# Altium schematic sheet EXOStripController.SchDoc
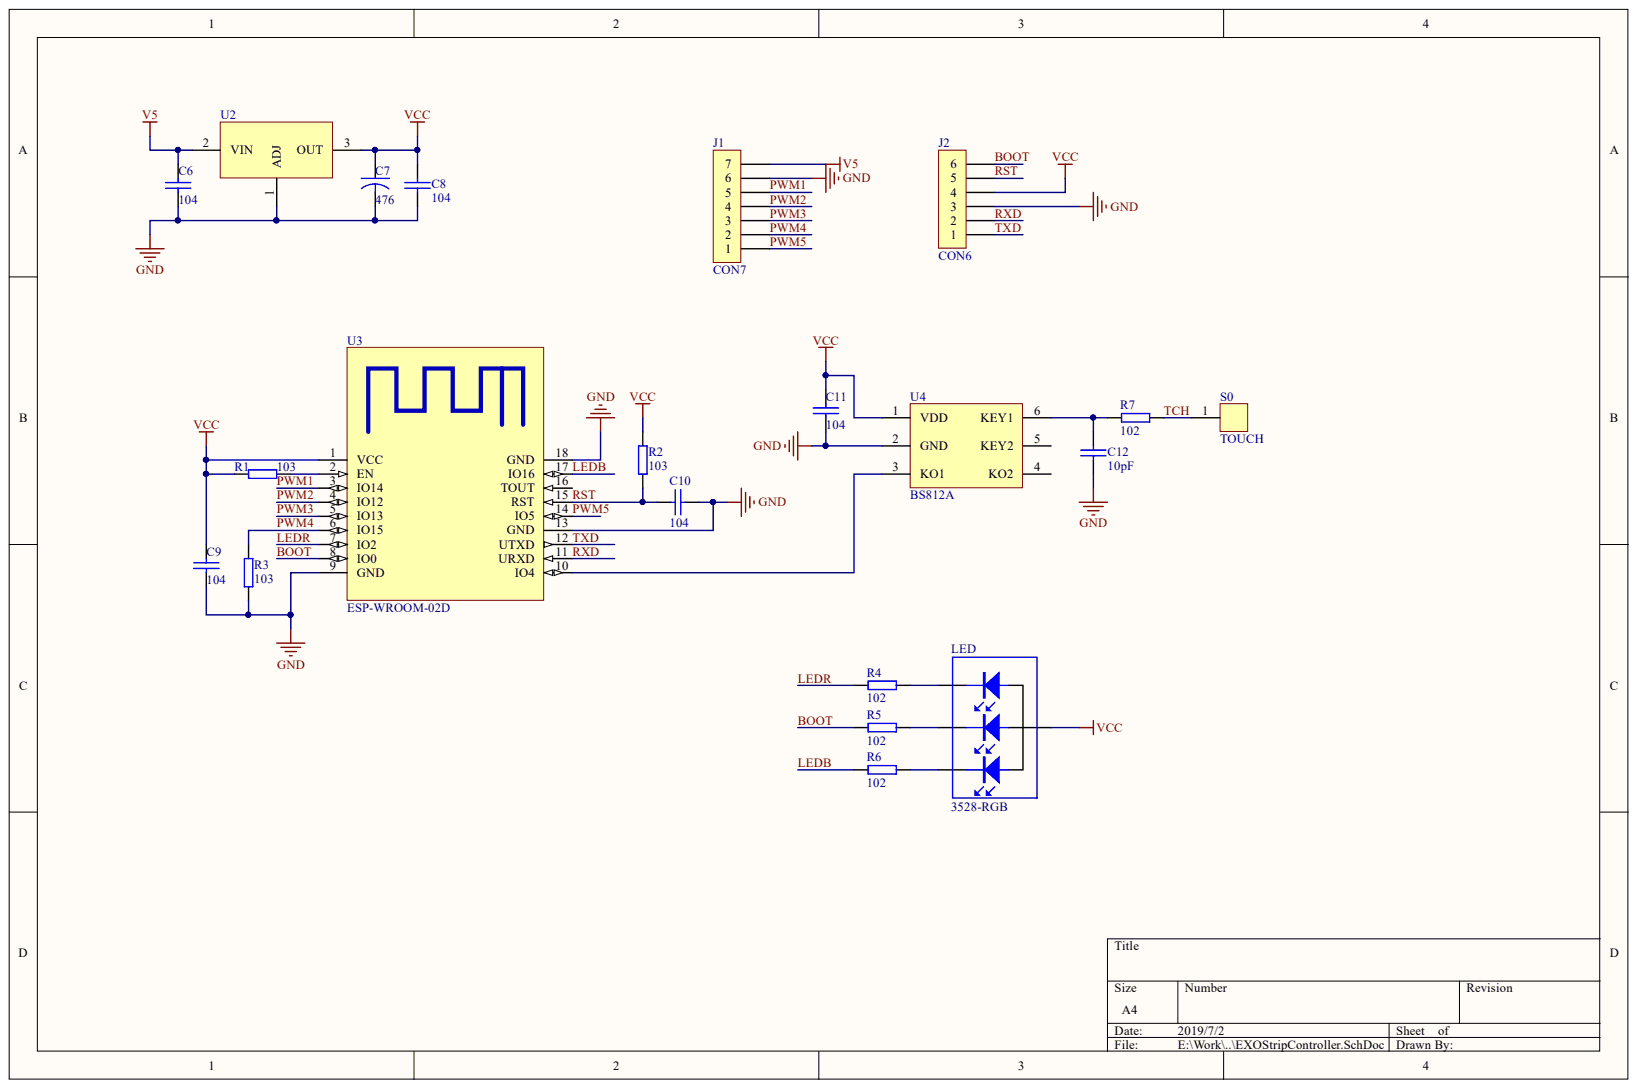
[diagram p0: EXOStripController.SchDoc]

## Parts
C6  104  [0603]
C7  476  [3216]
C8  104  [0603]
C9  104  [0603]
C10  104  [0603]
C11  104  [0603]
C12  10pF  [0603]
J1  CON7  [FPC-1mm*7]
J2  CON6  [J6]
LED  3528-RGB  [3528-RGB]
R1  103  [0603]
R2  103  [0603]
R3  103  [0603]
R4  102  [0603]
R5  102  [0603]
R6  102  [0603]
R7  102  [0603]
S0  TOUCH
U2  LD1117AG33  [SOT223]  (value not drawn)
U3  ESP-WROOM-02D  [ESP-WROOM-02D]
U4  BS812A  [TS0T23-6]

## Nets
GND: C6.2 C7.2 C8.2 C9.1 C10.1 C11.2 C12.2 J1.6 J2.3 R3.1 U2.1 U3.9(GND) U3.13(GND) U3.18(GND) U4.2(GND)
V5: C6.1 J1.7 U2.2
VCC: C7.1 C8.1 C9.2 C11.1 J2.4 LED.4 R1.1 R2.1 U2.3 U3.1(VCC) U4.1(VDD)
BOOT: J2.6 R5.1 U3.8(IO0)
LEDB: R6.1 U3.17(IO16)
LEDR: R4.1 U3.7(IO2)
PWM1: J1.5 U3.3(IO14)
PWM2: J1.4 U3.4(IO12)
PWM3: J1.3 U3.5(IO13)
PWM4: J1.2 R3.2 U3.6(IO15)
PWM5: J1.1 U3.14(IO5)
RST: C10.2 J2.5 R2.2 U3.15(RST)
RXD: J2.2 U3.11(URXD)
TCH: R7.2 S0.1
TXD: J2.1 U3.12(UTXD)
NetC12_1: C12.1 R7.1 U4.6(KEY1)
NetLED_1: LED.1 R4.2
NetLED_2: LED.2 R5.2
NetLED_3: LED.3 R6.2
NetR1_2: R1.2 U3.2(EN)
NetU3_10: U3.10(IO4) U4.3(KO1)

Not connected: U3 16(TOUT); U4 4(KO2) 5(KEY2)

## Library data of the parts
C6 C8-C12 = CAP: Capacitor; Component Kind: Standard; Library Name: 郑州创亿达照明原理图元件库.SCHLIB
C7 = CAPACITOR: Component Kind: Standard; Description: Capacitor; Library Name: 郑州创亿达照明原理图元件库.SCHLIB
J1 = CON7: Connector; Component Kind: Standard; Library Name: 郑州创亿达照明原理图元件库.SCHLIB
J2 = CON6: Connector; Component Kind: Standard; Library Name: 郑州创亿达照明原理图元件库.SCHLIB
LED = LED-RGB-2: Component Kind: Standard; Library Name: 郑州创亿达照明原理图元件库.SCHLIB
R1-R7 = RES2: Component Kind: Standard; Library Name: 郑州创亿达照明原理图元件库.SCHLIB
S0 = TOUCH: Component Kind: Standard; Library Name: 郑州创亿达照明原理图元件库.SCHLIB
U2 = LD1117AG33: Component Kind: Standard; Description: LD1117AG33; Library Name: 郑州创亿达照明原理图元件库.SCHLIB
U3 = ESP-WROOM-02D: Component Kind: Standard; Description: ESP-WROOM-02D; Library Name: 郑州创亿达照明原理图元件库.SCHLIB
U4 = BS812A: Component Kind: Standard; Library Name: 郑州创亿达照明原理图元件库.SCHLIB
